# Altium schematic sheet LISC_TopLevel.SchDoc(LISC_TopLevel)
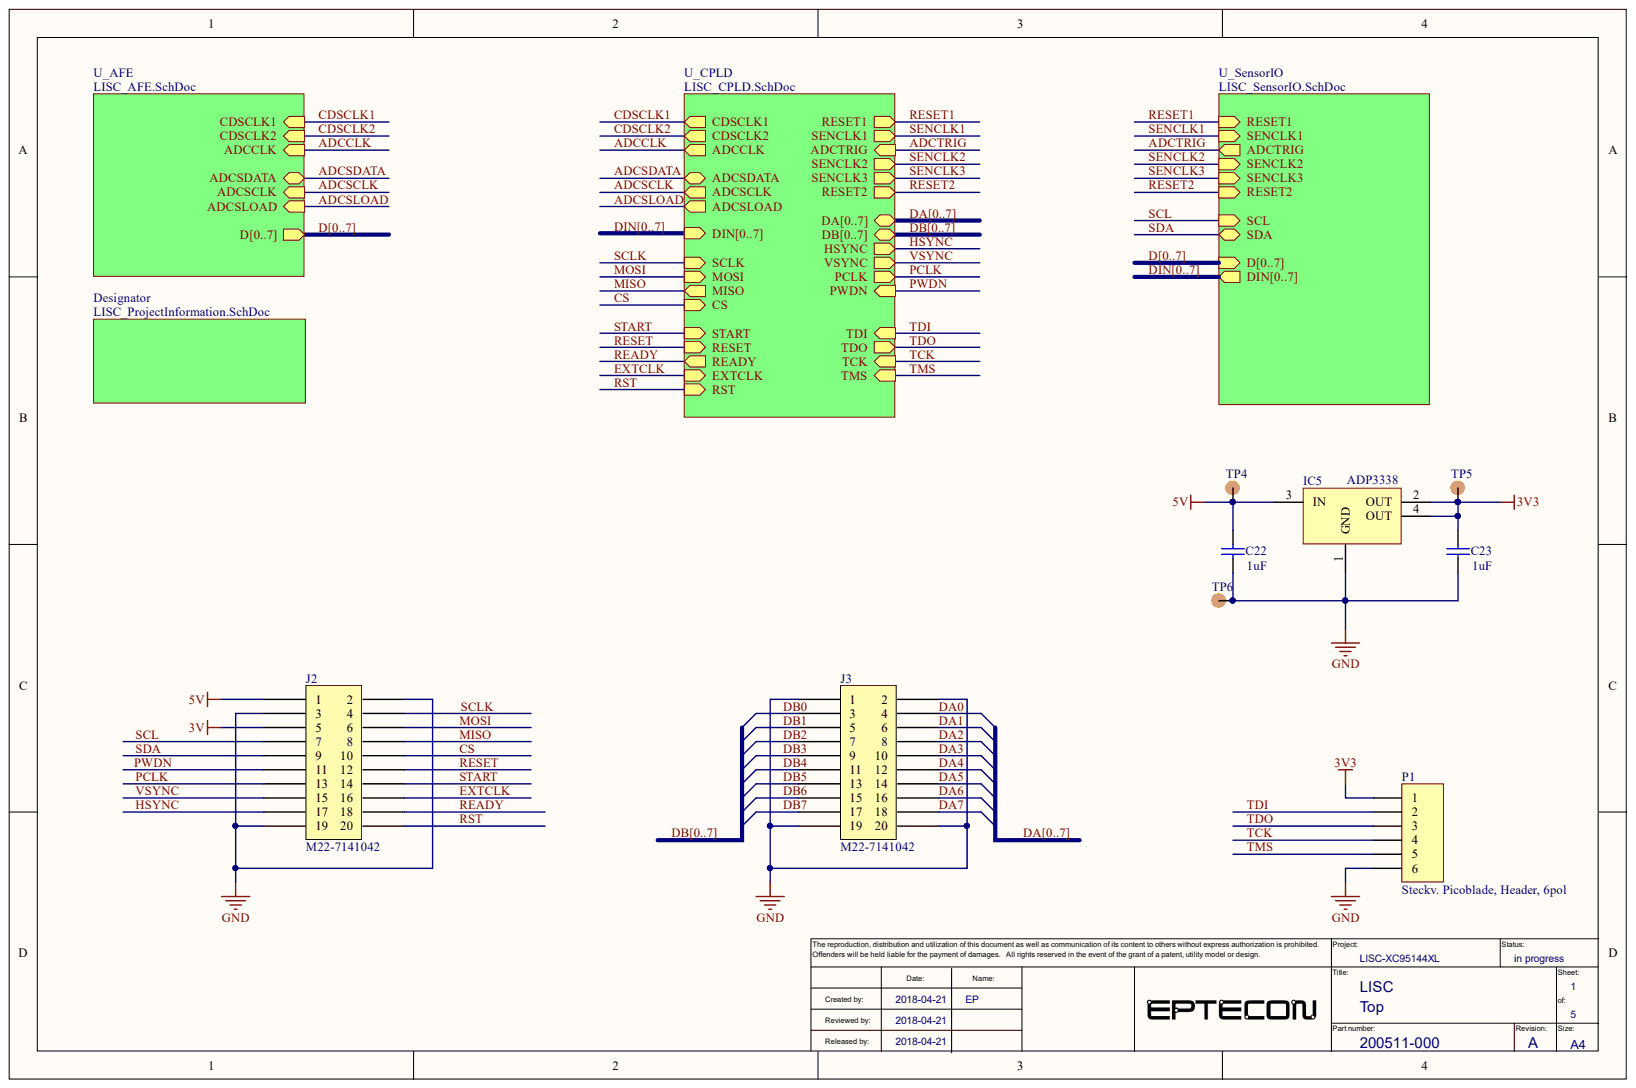
[diagram p0: LISC_TopLevel.SchDoc]

## Parts
C22  value 1uF  [1608[0603]]
C23  value 1uF  [1608[0603]]
IC5  ADP3338  [SOT223-4L]
J2  M22-7141042  [PCBComponent_1]
J3  M22-7141042  [PCBComponent_1]
P1  Steckv. Picoblade, Header, 6pol  [PicoBlade Header 6Pin gerade]
TP4  TP_SMD  [TP100_200_NS]  (value not drawn)
TP5  TP_SMD  [TP100_200_NS]  (value not drawn)
TP6  TP_SMD  [TP100_200_NS]  (value not drawn)

## Nets
3V: J2.5
3V3: C23.2 IC5.2(OUT) IC5.4(OUT) P1.1 TP5.1
5V: C22.2 IC5.3(IN) J2.1 TP4.1
GND: C22.1 C23.1 IC5.1(GND) J2.2 J2.3 J2.19 J3.1 J3.2 J3.19 J3.20 P1.6 TP6.1
CS: J2.10
DA0: J3.4  [also labelled DA]
DA1: J3.6  [also labelled DA]
DA2: J3.8  [also labelled DA]
DA3: J3.10  [also labelled DA]
DA4: J3.12  [also labelled DA]
DA5: J3.14  [also labelled DA]
DA6: J3.16  [also labelled DA]
DA7: J3.18  [also labelled DA]
DB0: J3.3  [also labelled DB]
DB1: J3.5  [also labelled DB]
DB2: J3.7  [also labelled DB]
DB3: J3.9  [also labelled DB]
DB4: J3.11  [also labelled DB]
DB5: J3.13  [also labelled DB]
DB6: J3.15  [also labelled DB]
DB7: J3.17  [also labelled DB]
EXTCLK: J2.16
HSYNC: J2.17
MISO: J2.8
MOSI: J2.6
PCLK: J2.13
PWDN: J2.11
READY: J2.18
RESET: J2.12
RST: J2.20
SCL: J2.7
SCLK: J2.4
SDA: J2.9
START: J2.14
TCK: P1.4
TDI: P1.2
TDO: P1.3
TMS: P1.5
VSYNC: J2.15
ADCCLK:
ADCSCLK:
ADCSDATA:
ADCSLOAD:
ADCTRIG:
CDSCLK1:
CDSCLK2:
D0:  [also labelled D]
D1:  [also labelled D]
D2:  [also labelled D]
D3:  [also labelled D]
D4:  [also labelled D]
D5:  [also labelled D]
D6:  [also labelled D]
D7:  [also labelled D]
DIN0:  [also labelled DIN]
DIN1:  [also labelled DIN]
DIN2:  [also labelled DIN]
DIN3:  [also labelled DIN]
DIN4:  [also labelled DIN]
DIN5:  [also labelled DIN]
DIN6:  [also labelled DIN]
DIN7:  [also labelled DIN]
RESET1:
RESET2:
SENCLK1:
SENCLK2:
SENCLK3:

## Library data of the parts
C22 C23 = Cap Semi: Capacitor (Semiconductor SIM Model); Component Kind: Standard; LatestRevisionDate: 29-May-2009; LatestRevisionNote: IPC-7351 Footprint Added.; Library Name: Miscellaneous Devices.IntLib; PackageDescription: Chip Capacitor; PackageReference: C1206; Published: 8-Jun-2000; Signal Integrity: Cap Semi; Simulation: CAP; Publisher: Altium Limited
IC5 = ADP3338: High Accuracy, Ultralow IQ, 1 A,  anyCAP® Low Dropout Regulator; Component Kind: Standard; Library Name: V-REG_LT.SchLib; Supplier 1: Farnell; Supplier Part Number 1: 1651283; Value: ADP3338
J2 J3 = M22-7141042: Harwig M22-7141042; Component Kind: Standard; Library Name: ConSpecial.SchLib
P1 = 1.25mm Pitch PicoBlade™ Wire-to-Board Header, Vertical, with Friction Lock, 6 Circuits: MOLEX - 53047-0610 - STECKER,VERTIKAL,6KONT; Anschlussart: Lötmittel; Anschlusstyp: Draht zu Platine; Body Plating: Zinn; Gehäusetyp: GF Nylon UL94V-0; Isolations-Widerstand: 100; Kontaktanschluss: Durchsteckmontage, vertikal; Kontakte, Anzahl der: 6; Kontaktmaterial: Phosphor-Bronze; Länge/Höhe, Außen-: 3.5; Min Betriebstemperatur: -40; Nennstrom: 1; Raster: 1.25; Spannung, Betriebs-: 125; Spannung, Steh-: 250; Temperatur, Betriebs- max.: 85; Tiefe, Außen: 5.5; Component Kind: Standard; ComponentLink1Description: http://www.farnell.com/cad/694680.pdf; ComponentLink1URL: http://www.farnell.com/cad/694680.pdf; ComponentLink2Description: http://www.farnell.com/datasheets/524695.pdf; ComponentLink2URL: http://www.farnell.com/datasheets/524695.pdf; Gender: Header; Kontaktüberzug: Zinn; Library Name: CON_MOLEX_LT.SchLib; Manufacturer: MOLEX; Manufacturer Part Number: 53047-0610; Pole, Anzahl der: 6; Pricing 1: 5=0,673, 100=0,42, 250=0,358, 500=0,34, 1000=0,311 (EUR); Raster, Anschluss: 1.25; Reihen, Anzahl der: 1; RoHS: YES; Serie: PicoBlade; Spannung, Nenn-, AC: 125; Steckverbindertyp: Draht zu Platine; Stock 1: 35787; Supplier 1: Farnell; Supplier Part Number 1: 9733078; Wege, Anzahl der: 6
TP4-TP6 = TP_SMD: Component Kind: Standard; Library Name: LISC-XC95144XL.SCHLIB
